# Altium schematic sheet Buttons.SchDoc(Buttons)
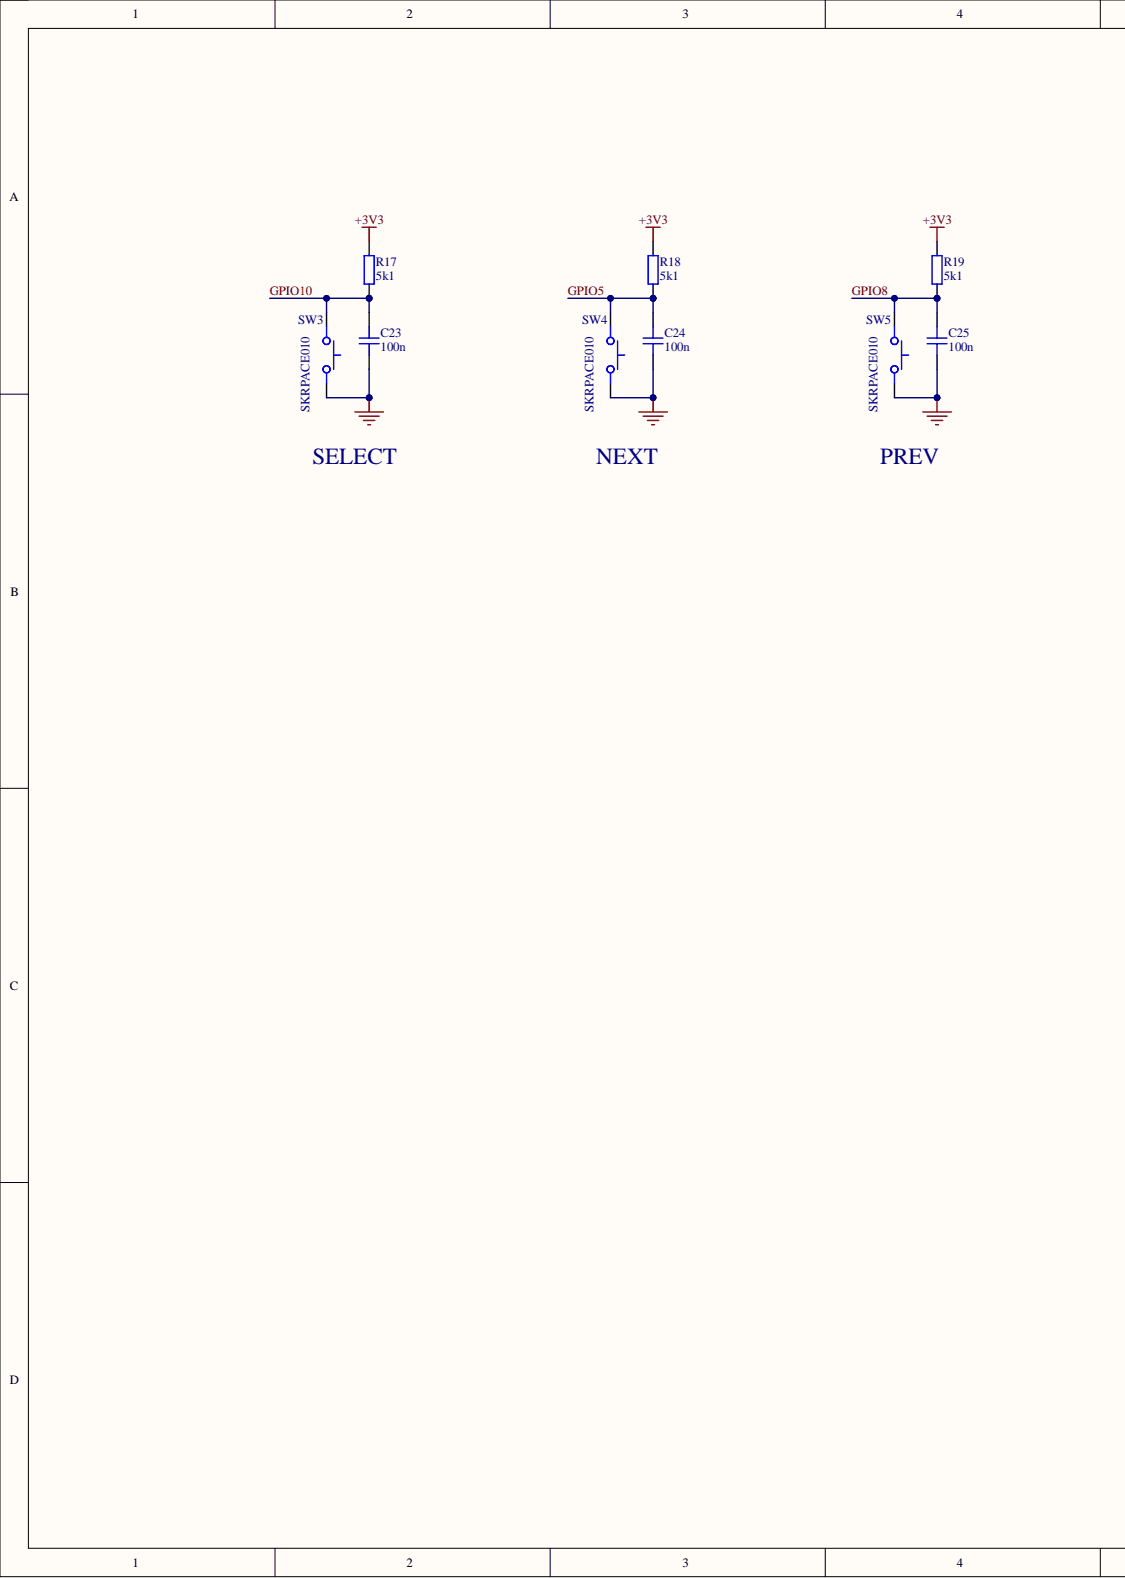
[diagram p0: Buttons.SchDoc, left]
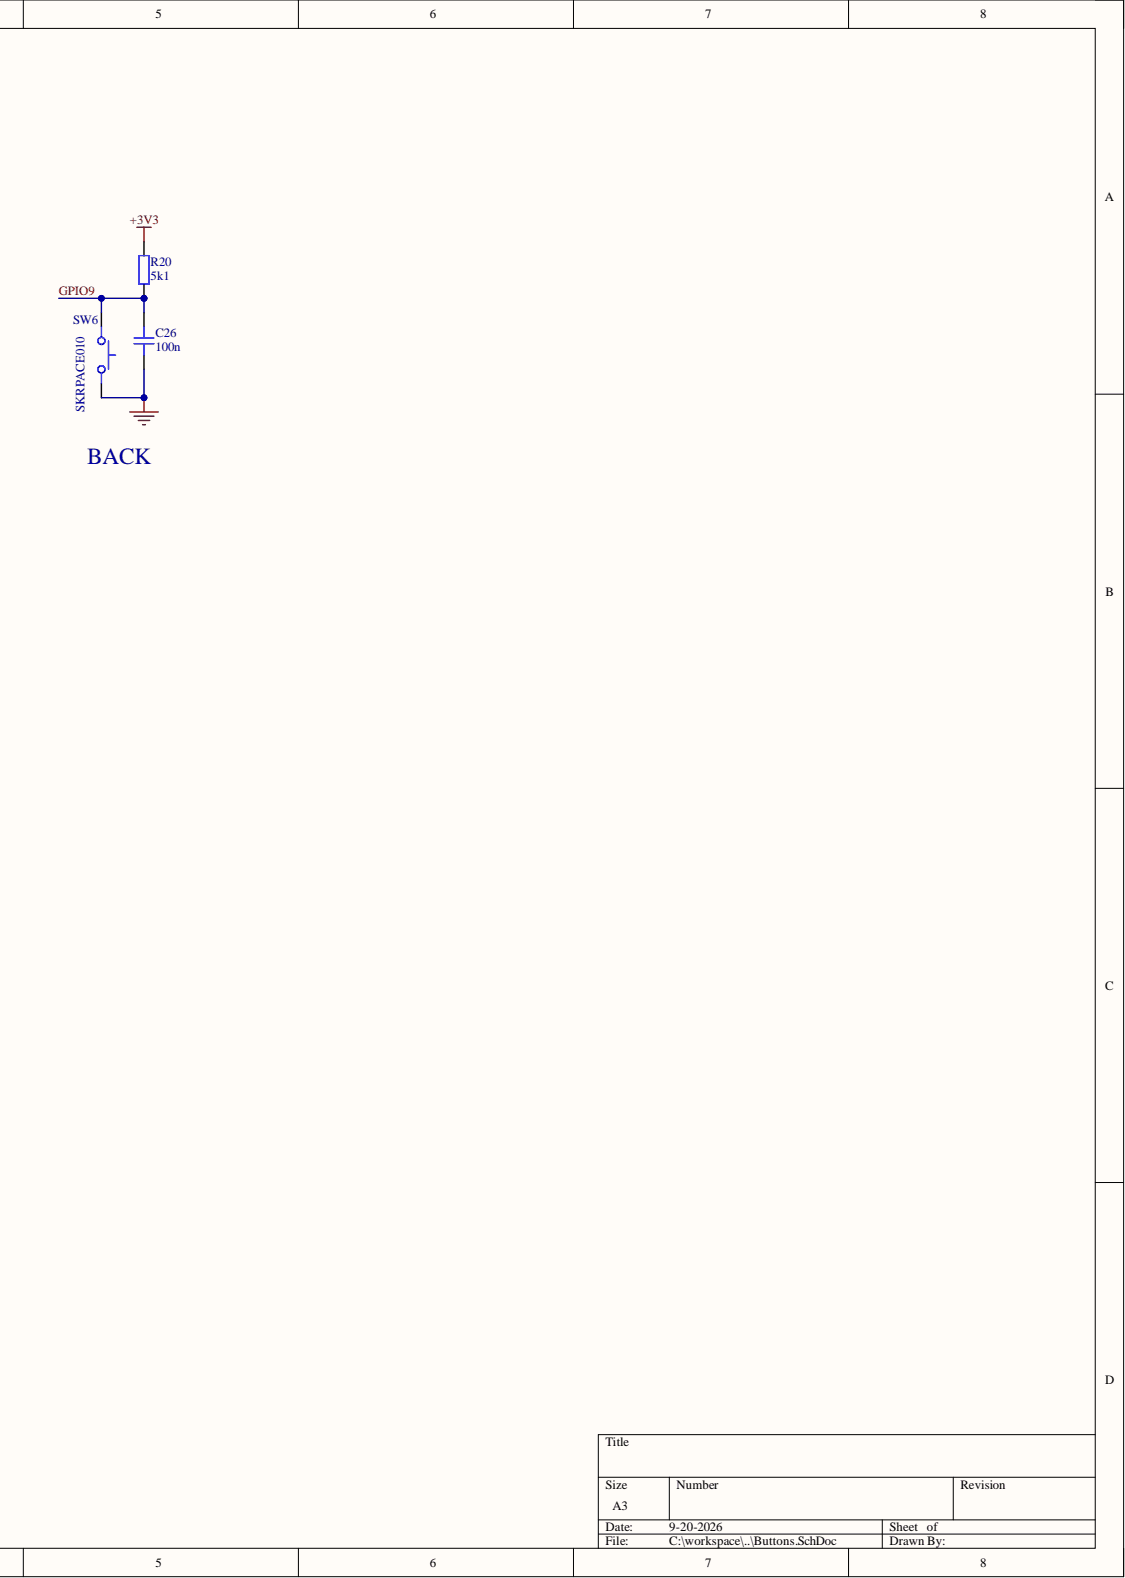
[diagram p1: Buttons.SchDoc, right]

## Parts
C23  100n  [CAP_0402]
C24  100n  [CAP_0402]
C25  100n  [CAP_0402]
C26  100n  [CAP_0402]
R17  5k1  [RES_0402]
R18  5k1  [RES_0402]
R19  5k1  [RES_0402]
R20  5k1  [RES_0402]
SW3  SKRPACE010  [SKRPANE010]
SW4  SKRPACE010  [SKRPANE010]
SW5  SKRPACE010  [SKRPANE010]
SW6  SKRPACE010  [SKRPANE010]

## Nets
+3V3: R17.2 R18.2 R19.2 R20.2
GND: C23.1 C24.1 C25.1 C26.1 SW3.12 SW4.12 SW5.12 SW6.12
GPIO5: C24.2 R18.1 SW4.34
GPIO8: C25.2 R19.1 SW5.34
GPIO9: C26.2 R20.1 SW6.34
GPIO10: C23.2 R17.1 SW3.34

## Library data of the parts
C23-C26 = CAP_0402_50V: Capacitor SMD 0402 50V; Manufacturer: Samsung; Manufacturer Part Number: CL05B104KO5NNNC; Component Kind: Standard; LCSC: C1525; Library Name: Schematic-Lib-PhilsLab.SchLib
R17-R20 = RES_0402: Resistor SMD 0402 5.1k; Manufacturer: Uniroyal; Manufacturer Part Number: 0402WGF5101TCE; Component Kind: Standard; LCSC: C25905; Library Name: Schematic-Lib-PhilsLab.SchLib
SW3-SW6 = SKRPANE010: Tactile Switch 2.6N 3.2mm 4.2mm 50,000 cycles 50mA J-Lead Oval button SPST-NO Surface Mount,Vertical SMD-4P,4.2x3.2mm; Manufacturer: Alps Alpine; Component Kind: Standard; LCSC: C139797; Manufacturer Part Number: SKRPACE010; Library Name: Schematic-Lib-PhilsLab.SchLib
